# Altium schematic sheet enc-abs_piso_1.0.SchDoc
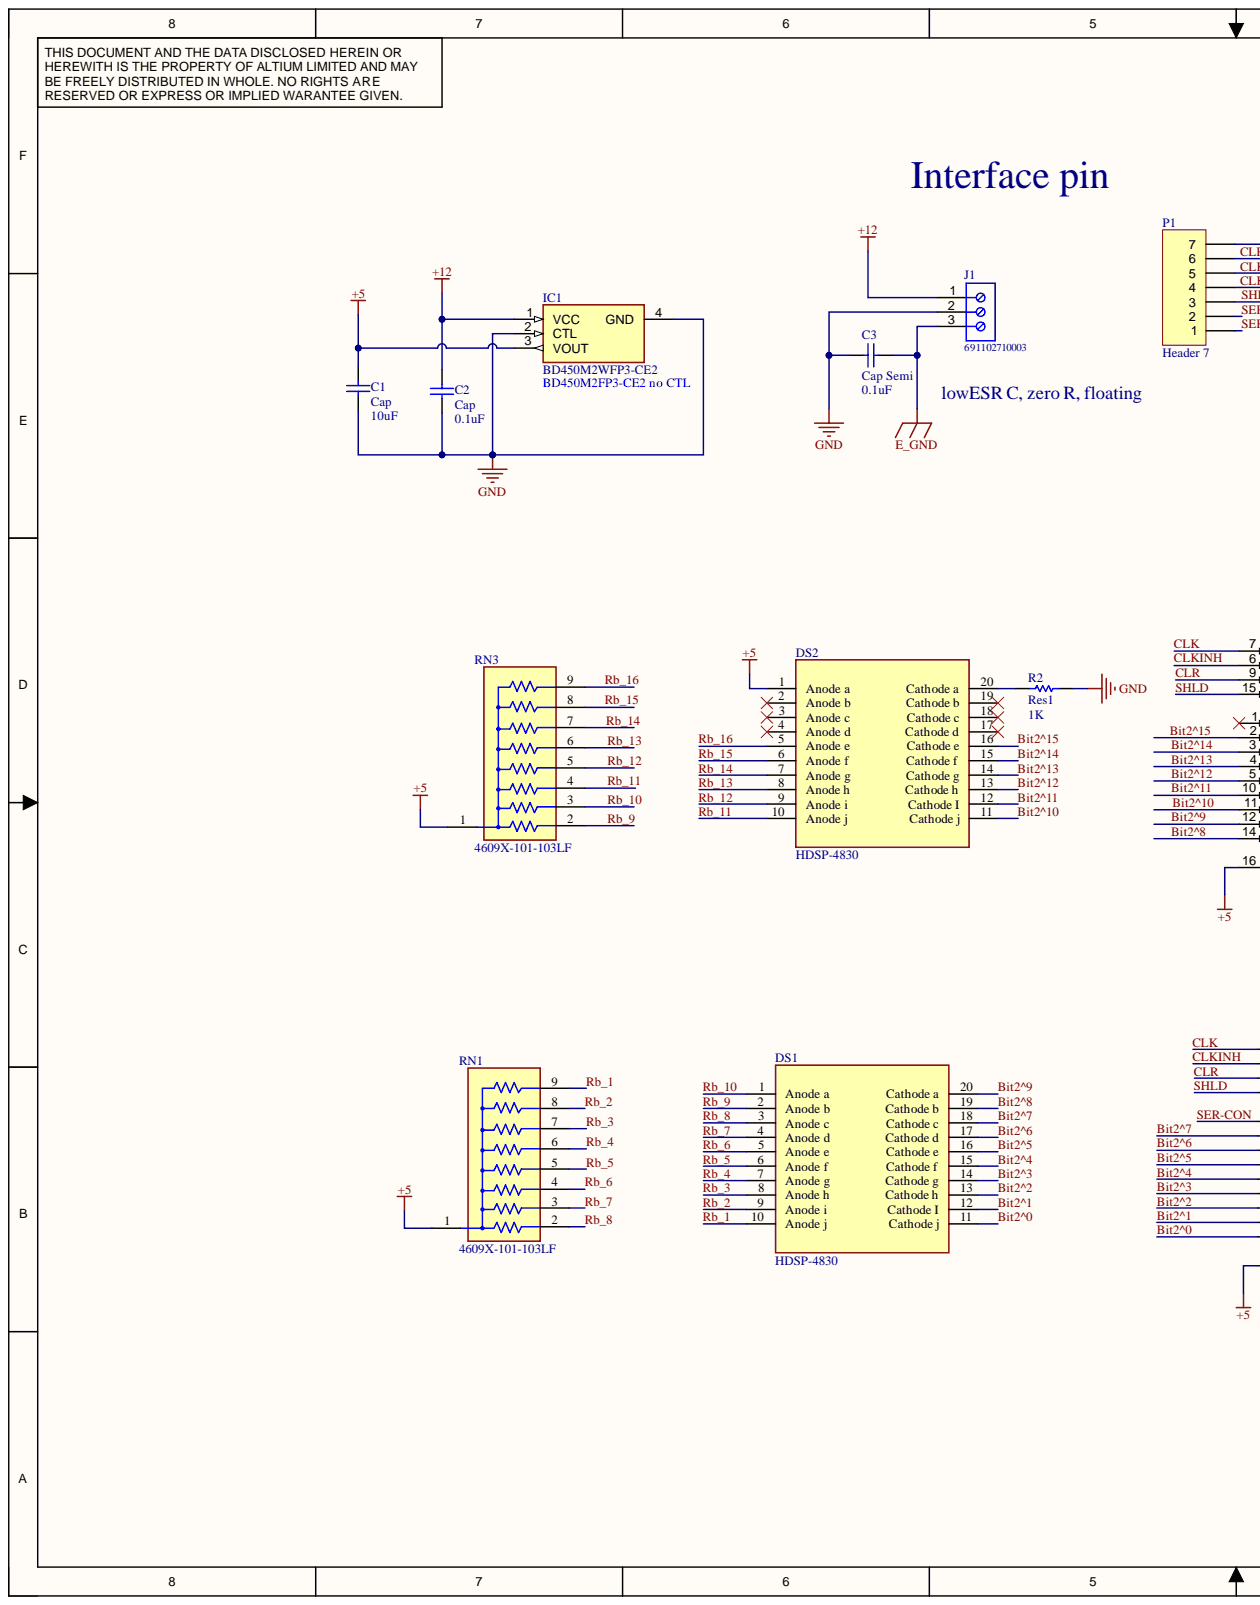
[diagram p0: enc-abs_piso_1.0.SchDoc, left]
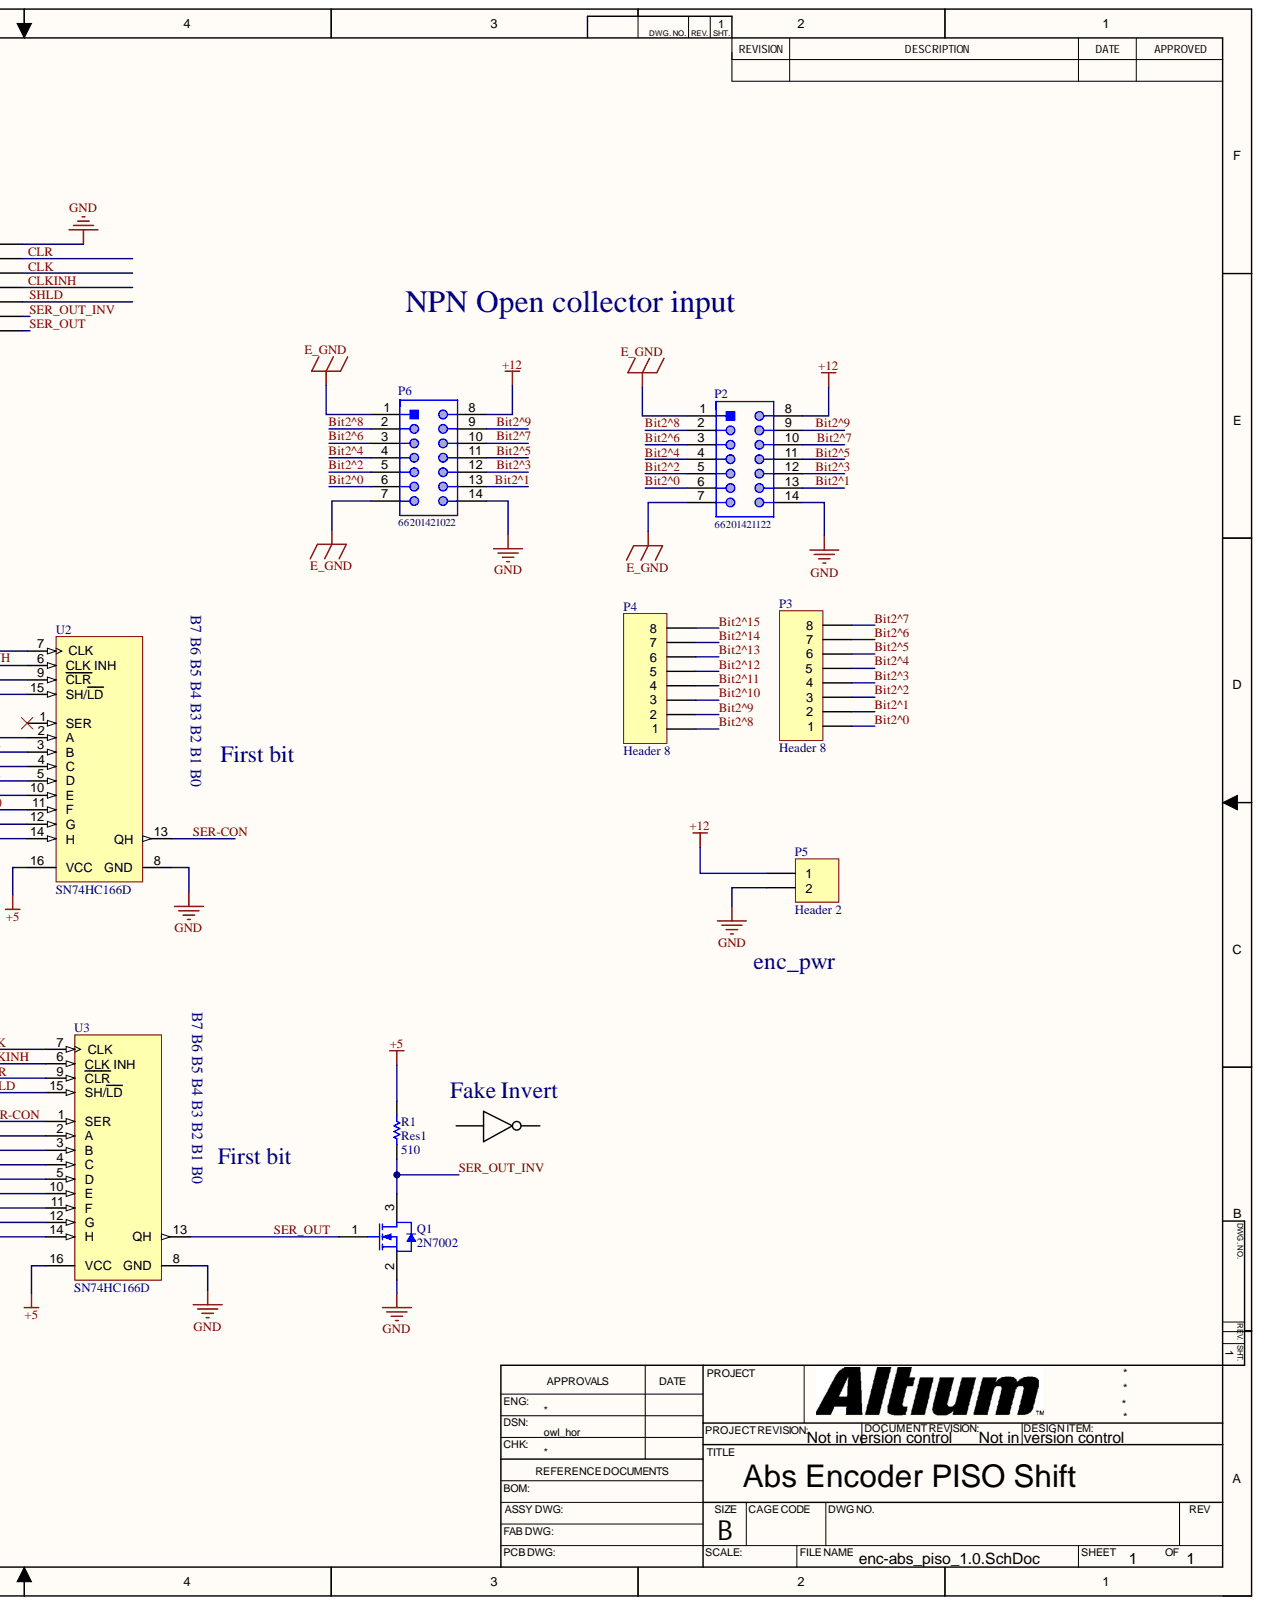
[diagram p1: enc-abs_piso_1.0.SchDoc, right]

## Parts
C1  Cap  value 10uF  [RAD-0.3]
C2  Cap  value 0.1uF  [RAD-0.3]
C3  Cap Semi  value 0.1uF  [C1206]
DS1  HDSP-4830  [Broadcom_HDSP-4830_0]
DS2  HDSP-4830  [Broadcom_HDSP-4830_0]
IC1  BD450M2WFP3-CE2  [SOT230P700X170-4N]
J1  691102710003  [691102710003]
P1  Header 7  [HDR1X7]
P2  66201421122  [66201421122]
P3  Header 8  [HDR1X8]
P4  Header 8  [HDR1X8]
P5  Header 2  [HDR1X2]
P6  66201421022  [66201421022]
Q1  2N7002  [STM-SOT-23-3_V]
R1  Res1  value 510  [AXIAL-0.3]
R2  Res1  value 1K  [Res_1206_3mm]
RN1  4609X-101-103LF  [FP-4609X-MFG]
RN3  4609X-101-103LF  [FP-4609X-MFG]
U2  SN74HC166D  [D0016A_M]
U3  SN74HC166D  [D0016A_M]

## Nets
+5: C1.2 DS2.1(Anode_a) IC1.3(VOUT) R1.2 RN1.1 RN3.1 U2.16(VCC) U3.16(VCC)
+12: C2.2 IC1.1(VCC) J1.1 P2.8 P5.1 P6.8
E_GND: C3.2 J1.3 P2.1 P2.7 P6.1 P6.7
GND: C1.1 C2.1 C3.1 IC1.2(CTL) IC1.4(GND) J1.2 P1.7 P2.14 P5.2 P6.14 Q1.2 R2.1 U2.8(GND) U3.8(GND)
Bit2^0: DS1.11(Cathode_j) P2.6 P3.1 P6.6 U3.14(H)
Bit2^1: DS1.12(Cathode_I) P2.13 P3.2 P6.13 U3.12(G)
Bit2^2: DS1.13(Cathode_h) P2.5 P3.3 P6.5 U3.11(F)
Bit2^3: DS1.14(Cathode_g) P2.12 P3.4 P6.12 U3.10(E)
Bit2^4: DS1.15(Cathode_f) P2.4 P3.5 P6.4 U3.5(D)
Bit2^5: DS1.16(Cathode_e) P2.11 P3.6 P6.11 U3.4(C)
Bit2^6: DS1.17(Cathode_d) P2.3 P3.7 P6.3 U3.3(B)
Bit2^7: DS1.18(Cathode_c) P2.10 P3.8 P6.10 U3.2(A)
Bit2^8: DS1.19(Cathode_b) P2.2 P4.1 P6.2 U2.14(H)
Bit2^9: DS1.20(Cathode_a) P2.9 P4.2 P6.9 U2.12(G)
Bit2^10: DS2.11(Cathode_j) P4.3 U2.11(F)
Bit2^11: DS2.12(Cathode_I) P4.4 U2.10(E)
Bit2^12: DS2.13(Cathode_h) P4.5 U2.5(D)
Bit2^13: DS2.14(Cathode_g) P4.6 U2.4(C)
Bit2^14: DS2.15(Cathode_f) P4.7 U2.3(B)
Bit2^15: DS2.16(Cathode_e) P4.8 U2.2(A)
CLK: P1.5 U2.7(CLK) U3.7(CLK)
CLKINH: P1.4 U2.6(CLK_INH) U3.6(CLK_INH)
CLR: P1.6 U2.9(CLR) U3.9(CLR)
Rb_1: DS1.10(Anode_j) RN1.9
Rb_2: DS1.9(Anode_i) RN1.8
Rb_3: DS1.8(Anode_h) RN1.7
Rb_4: DS1.7(Anode_g) RN1.6
Rb_5: DS1.6(Anode_f) RN1.5
Rb_6: DS1.5(Anode_e) RN1.4
Rb_7: DS1.4(Anode_d) RN1.3
Rb_8: DS1.3(Anode_c) RN1.2
Rb_9: DS1.2(Anode_b) RN3.2
Rb_10: DS1.1(Anode_a) RN3.3
Rb_11: DS2.10(Anode_j) RN3.4
Rb_12: DS2.9(Anode_i) RN3.5
Rb_13: DS2.8(Anode_h) RN3.6
Rb_14: DS2.7(Anode_g) RN3.7
Rb_15: DS2.6(Anode_f) RN3.8
Rb_16: DS2.5(Anode_e) RN3.9
SER-CON: U2.13(QH) U3.1(SER)
SER_OUT: P1.1 Q1.1 U3.13(QH)
SER_OUT_INV: P1.2 Q1.3 R1.1
SHLD: P1.3 U2.15(SH/LD) U3.15(SH/LD)
NetDS2_20: DS2.20(Cathode_a) R2.2

Not connected: DS2 2(Anode_b) 3(Anode_c) 4(Anode_d) 17(Cathode_d) 18(Cathode_c) 19(Cathode_b); U2 1(SER)

## Library data of the parts
C1 C2 = Cap: Capacitor; Component Kind: Standard; LatestRevisionDate: 17-Jul-2002; LatestRevisionNote: Re-released for DXP Platform.; Library Name: Miscellaneous Devices.IntLib; PackageDescription: Radial Cap, Thru-Hole; 2 Leads; 0.3 in Pin Spacing; PackageReference: RAD-0.3; Published: 8-Jun-2000; Signal Integrity: Cap; Simulation: CAP; Publisher: Altium Limited
C3 = Cap Semi: Capacitor (Semiconductor SIM Model); Component Kind: Standard; LatestRevisionDate: 29-May-2009; LatestRevisionNote: IPC-7351 Footprint Added.; Library Name: Miscellaneous Devices.IntLib; PackageDescription: Chip Capacitor; PackageReference: C1206; Published: 8-Jun-2000; Signal Integrity: Cap Semi; Simulation: CAP; Publisher: Altium Limited
DS1 DS2 = HDSP-4830: 10 Segments LED Array; Component Kind: Standard; Library Name: Schlib1.SchLib
IC1 = BD450M2WFP3-CE2: Integrated Circuit; Manufacturer_Name: ROHM Semiconductor; Component Kind: Standard; Datasheet Link: https://componentsearchengine.com/Datasheets/1/BD450M2WFP3-CE2.pdf; Height: 1.7mm; Manufacturer_Part_Number: BD450M2WFP3-CE2; Mouser Part Number: 755-BD450M2WFP3-CE2; Mouser Price/Stock: https://www.mouser.co.uk/ProductDetail/ROHM-Semiconductor/BD450M2WFP3-CE2?qs=pXoXL5qUNJ0Rp1aMnIGBZQ%3D%3D
J1 = CMP-1502-03259-1: Series 102 - 5.00 mm Horizontal Entry Modular with Pressure Clamp WR-TBL, 3 pin; Manufacturer: Wurth Elektronik; Pin Number: 3; Pitch: 5.00mm; Component Kind: Standard; Datasheet URL: http://katalog.we-online.de/em/datasheet/6911027100xx.pdf; Library Name: Altium Content Vault; Manufacturer URL: http://www.we-online.de; Mounting Technology: THT; Operating Temperature: -40to105degC; Package Reference: 691102710003; Packaging: Box; Part Number: 691102710003; Publisher: WurthElektronik
P1 = Header 7: Header, 7-Pin; Component Kind: Standard; LatestRevisionDate: 17-Jul-2002; LatestRevisionNote: Re-released for DXP Platform.; Library Name: Miscellaneous Connectors.IntLib; Signal Integrity: Connector; Publisher: Altium Limited
P2 = CMP-1502-00314-1: THT Male Header WR-MPC3, Vertical, Dual Row, pitch 3 mm, 14 pins; Manufacturer: Wurth Elektronik; Contact Resistance: 10mOhm; Pin Number: 14; Pitch: 3mm; Working Voltage: 250VAC; Component Kind: Standard; Datasheet URL: https://katalog.we-online.de/em/datasheet/6620xx21122.pdf; Gender: Male; Library Name: Altium Content Vault; Manufacturer URL: http://www.we-online.de; Mounting Technology: THT; Operating Temperature: -40to105degC; Orientation: Vertical; Package Reference: 66201421122; Packaging: Tube; Part Number: 66201421122; Publisher: WurthElektronik
P3 P4 = Header 8: Header, 8-Pin; Component Kind: Standard; LatestRevisionDate: 17-Jul-2002; LatestRevisionNote: Re-released for DXP Platform.; Library Name: Miscellaneous Connectors.IntLib; Signal Integrity: Connector; Publisher: Altium Limited
P5 = Header 2: Header, 2-Pin; Component Kind: Standard; LatestRevisionDate: 17-Jul-2002; LatestRevisionNote: Re-released for DXP Platform.; Library Name: Miscellaneous Connectors.IntLib; Signal Integrity: Connector; Publisher: Altium Limited
P6 = CMP-1502-00370-1: THT Male Header WR-MPC3, with Snap-in Plastic Pegs, Right Angled, Dual Row, pitch 3 mm, 14 pins; Manufacturer: Wurth Elektronik; Contact Resistance: 10mOhm; Pin Number: 14; Pitch: 3mm; Working Voltage: 250VAC; Component Kind: Standard; Datasheet URL: https://katalog.we-online.de/em/datasheet/6620xx21022.pdf; Gender: Male; Library Name: Altium Content Vault; Manufacturer URL: http://www.we-online.de; Mounting Technology: THT; Operating Temperature: -40to105degC; Orientation: RightAngled; Package Reference: 66201421022; Packaging: Tube; Part Number: 66201421022; Publisher: WurthElektronik
Q1 = CMP-0434-00001-1: N-channel 60 V, 1.8 Ohm, 0.35 A STripFET(TM) II Power MOSFET, SOT23; Manufacturer: STMicroelectronics; Component Kind: Standard; ComponentLink1Description: Manufacturer URL; ComponentLink1URL: http://www.st.com/; ComponentLink2Description: Datasheet; ComponentLink2URL: http://www.st.com/st-web-ui/static/active/en/resource/technical/document/datasheet/CD00005134.pdf; DatasheetVersion: Rev. 9, 11/2008; Library Name: Altium Content Vault; Mounting Technology: Surface Mount; PackageDescription: 3-Pin SOT23, 3.016 x 2.8 mm Body, 0.95 mm Pitch; PackageReference: SOT-23; PartNumber: 2N7002
R1 R2 = Res1: Resistor; Component Kind: Standard; LatestRevisionDate: 17-Jul-2002; LatestRevisionNote: Re-released for DXP Platform.; Library Name: Miscellaneous Devices.IntLib; PackageDescription: Axial Device, Thru-Hole; 2 Leads; 0.3 in Pin Spacing; PackageReference: AXIAL-0.3; Published: 8-Jun-2000; Signal Integrity: Res; Simulation: RESISTOR; Publisher: Altium Limited
RN1 RN3 = CMP-2000-05605-2: RES ARRAY 8 RES 10K OHM 9SIP; Circuit Type: Bussed; Insulation Resistance: 10MR; Lead Length: 3.43mm; Number of Elements: 8; Number of Terminals/Pins: 9; Power Per Element: 200mW; Power Rating: 1.13W; Resistance: 10kR; Resistance Tolerance: 2%; Temperature Coefficient: 100ppm/°C; Voltage Rating: 100V; Case/Package: SIP; Component Kind: Standard; Contact Plating: Copper; Depth: 2.49mm; Height: 0.2inch; Lead Pitch: 2.49mm; Length: 22.81mm; Library Name: Altium Content Vault; Max Operating Temperature: 125°C; Military Standard: Not; Min Operating Temperature: -55°C; Mount: Through Hole; Number of Pins: 9; Number of Resistors: 8; Package Quantity: 200; Packaging: Bulk; Radiation Hardening: No; REACH SVHC: No SVHC; RoHS Compliant: Yes; Series: 4600X; Technology: THICK FILM; Terminal Pitch: 2.54mm; Termination: Pin; Tolerance: 2%; Width: 0.098inch
U2 U3 = CMP-0780-00056-3: 8-Bit Parallel-Load Shift Registers, D0016A, TUBE; Manufacturer: Texas Instruments; 3-State Output: No; Bits: 8; F @ Nom Voltage(Max)(Mhz): 28; ICC @ Nom Voltage(Max)(mA): 0.08; Input Type: CMOS; Output Drive (IOL/IOH)(Max)(mA): 5.2/-5.2; Rating: Catalog; Technology Family: HC; tpd @ Nom Voltage(Max)(ns): 32; VCC(Max)(V): 6; VCC(Min)(V): 2; Code_JEDEC: MS-012 variation AC; Component Kind: Standard; DatasheetVersion: SCLS117D; Generic Part Number: SN74HC166; Library Name: Altium Content Vault; Operating Temperature Range(C): -40 to 85; Output Type: CMOS; PackageReference: D0016A; PackageVersion: http://www.ti.com/lit/ml/mpds178g/mpds178g.pdf; Voltage(Nom)(V): 6
